# Altium schematic sheet Potencia.SchDoc
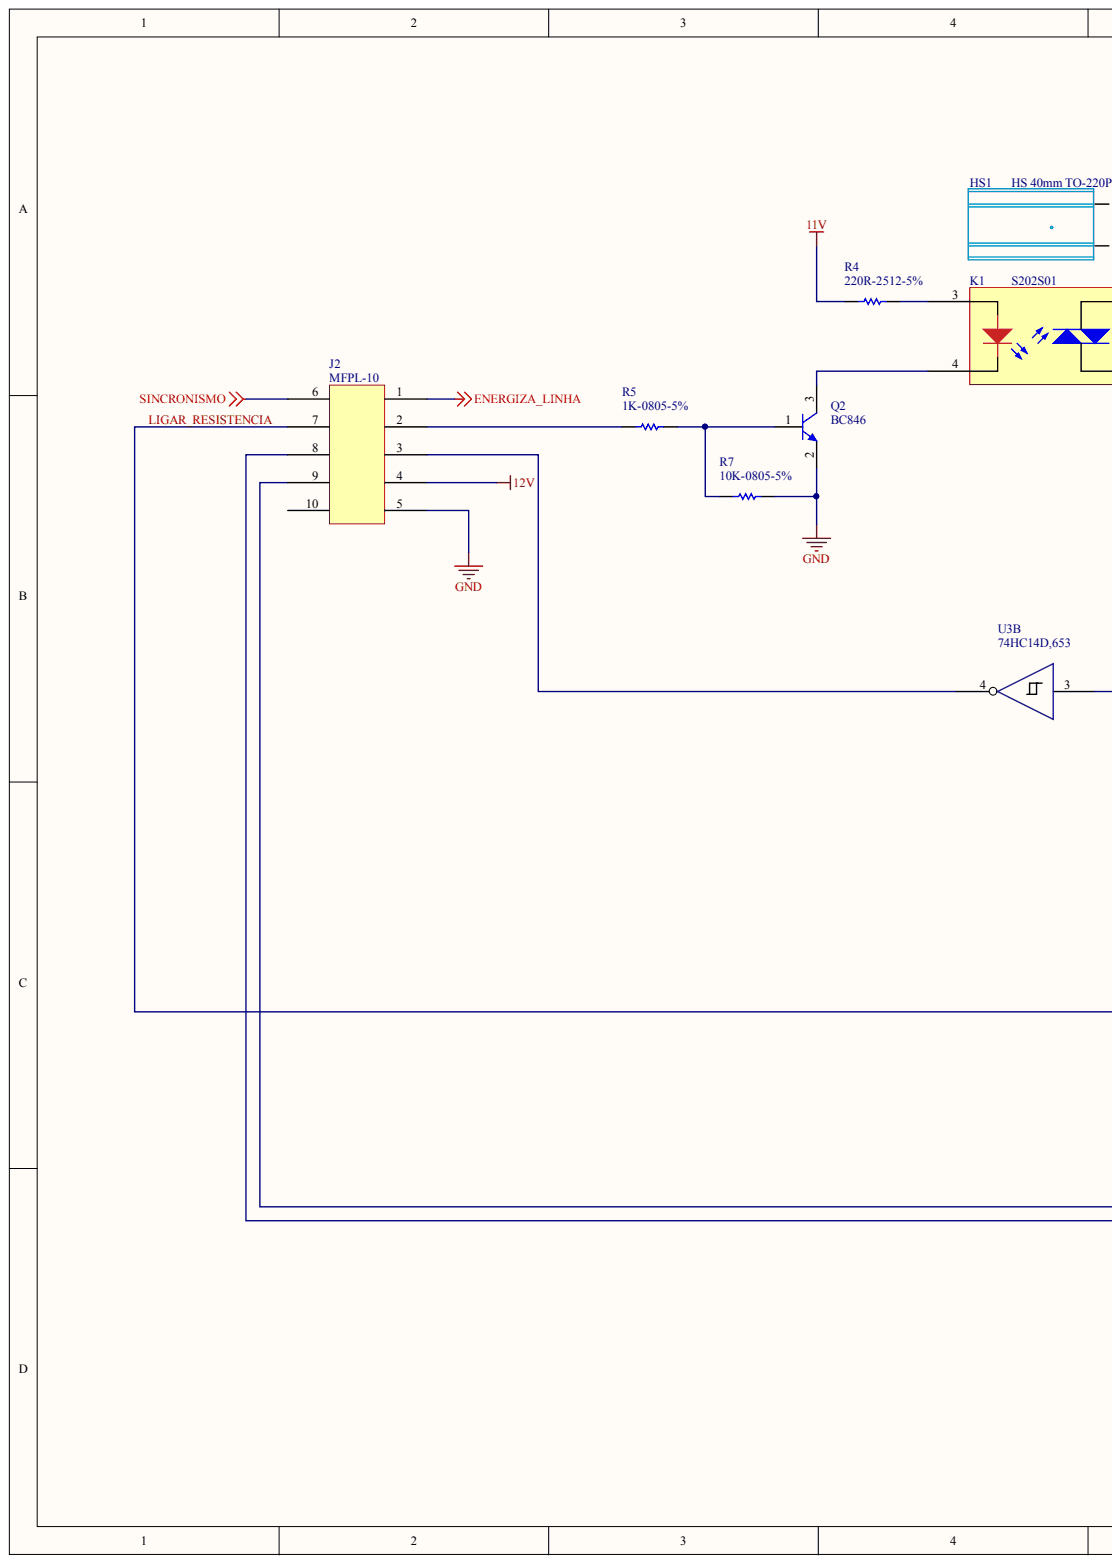
[diagram p0: Potencia.SchDoc, left]
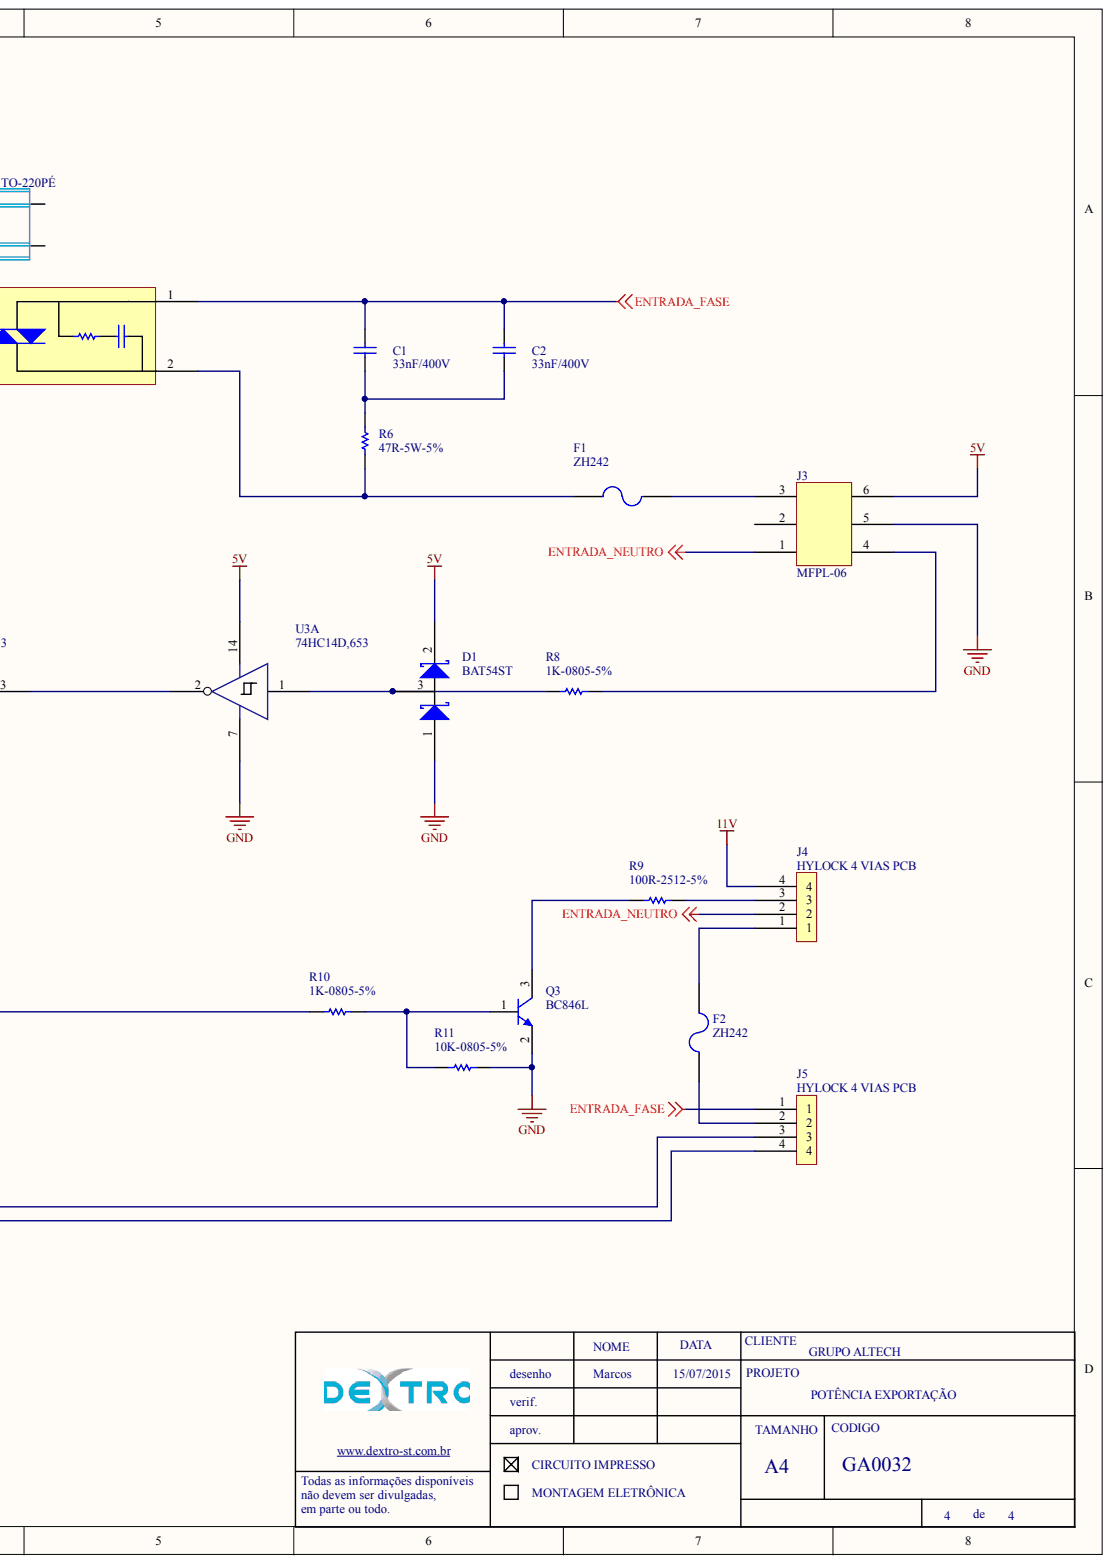
[diagram p1: Potencia.SchDoc, right]

## Parts
C1  33nF/400V  [cap 330nF/250V]
C2  33nF/400V  [cap 330nF/250V]
D1  BAT54ST  [SOT23 - REVISADO ONDA]
F1  ZH242  [ZH242_REVISADO]
F2  ZH242  [ZH242_REVISADO]
HS1  HS 40mm TO-220PÉ  [dissipador grande]
J2  MFPL-10  [MFPC-10]
J3  MFPL-06  [MFPC-06]
J4  HYLOCK 4 VIAS PCB  [CONECTOR HYLOK 4 VIAS]
J5  HYLOCK 4 VIAS PCB  [CONECTOR HYLOK 4 VIAS]
K1  S202S01  [S202S02]
Q2  BC846  [SOT23 - REVISADO ONDA]
Q3  BC846L
R4  220R-2512-5%  [2512 - REVISADO ONDA]
R5  1K-0805-5%  [0805-REVISADO ONDA]
R6  47R-5W-5%  [RESISTOR 5W FIO]
R7  10K-0805-5%  [0805-REVISADO ONDA]
R8  1K-0805-5%  [0805-REVISADO ONDA]
R9  100R-2512-5%  [2512 - REVISADO ONDA]
R10  1K-0805-5%  [0805-REVISADO ONDA]
R11  10K-0805-5%  [0805-REVISADO ONDA]
U3  74HC14D,653  [SOIC 14 PINOS]  (parts A,B)

## Nets
5V: D1.2 J3.6 U3.14
11V: J4.4 R4.2
12V: J2.4
ENERGIZA_LINHA: J2.1
ENTRADA_FASE: C1.2 C2.2 J5.1 K1.1
ENTRADA_NEUTRO: J3.1 J4.2
GND: D1.1 J2.5 J3.5 Q2.2 Q3.2 R7.2 R11.2 U3.7
SINCRONISMO: J2.6
LIGAR_RESISTENCIA: J2.7 R10.1
NetC1_1: C1.1 C2.1 R6.1
NetD1_3: D1.3 R8.1 U3.1
NetF1_1: F1.1 J3.3
NetF1_2: F1.2 K1.2 R6.2
NetF2_1: F2.1 J4.1
NetF2_2: F2.2 J5.2
NetJ2_2: J2.2 R5.1
NetJ2_3: J2.3 U3.4
NetJ2_8: J2.8 J5.4
NetJ2_9: J2.9 J5.3
NetJ3_4: J3.4 R8.2
NetJ4_3: J4.3 R9.2
NetK1_3: K1.3 R4.1
NetK1_4: K1.4 Q2.3
NetQ2_1: Q2.1 R5.2 R7.1
NetQ3_1: Q3.1 R10.2 R11.1
NetQ3_3: Q3.3 R9.1
NetU3_2: U3.2 U3.3

Not connected: HS1 0 1; J2 10; J3 2

## Library data of the parts
C1 C2 = 100nF/50-0805-NPO: Component Kind: Standard; Description: 100nF/50-0805-NPO; ERP: 104.11.005; Library Name: AquinoLib.SchLib
F1 F2 = PORTA FUSÍVEL TIPO BERÇO: Component Kind: Standard; Description: PORTA FUSÍVEL TIPO BERÇO; ERP: 426.00.002; Library Name: ChaveDigital3.SchLib
HS1 = DISSIPADOR 40mm PÉ: Component Kind: Standard; Library Name: ChaveDigital3.SchLib
J4 J5 = PCMC2-4: Component Kind: Standard; Description: PCMC2-4; Library Name: AquinoLib.SchLib
K1 = S102S12: Component Kind: Standard; Description: S102S12; Library Name: AquinoLib.SchLib
Q2 = BC846: BC846L; Component Kind: Standard; ERP: 106.22.001; Library Name: AquinoLib.SchLib
Q3 = BC846: Component Kind: Standard; Description: BC846L; ERP: 106.22.001; Library Name: AquinoLib.SchLib
R5 = 10K-0805-5%: Component Kind: Standard; Description: 10K-0805-5%; ERP: 102.11.054; Library Name: AquinoLib.SchLib
R9 = 100R-0805-5%: Component Kind: Standard; Description: 100R-0805-5%; ERP: 102.11.031; Library Name: AquinoLib.SchLib
U3 = 74HC14D: Component Kind: Standard; Description: 74HC14D; Library Name: AquinoLib.SchLib
D1 = BAT54ST: Component Kind: Standard; Description: BAT54ST; Library Name: AquinoLib.SchLib
J2 = MFPL-10: Component Kind: Standard; Description: MFPL-10; Library Name: AquinoLib.SchLib
J3 = MFPL-06: Component Kind: Standard; Description: MFPL-06; Library Name: AquinoLib.SchLib
R4 = 220R-2512-5%: Component Kind: Standard; Description: 220R-2512-5%; ERP: 102.31.000; Library Name: AquinoLib.SchLib
R6 = 47R-5W-5%: Component Kind: Standard; Description: 47R-5W-5%; ERP: 103.16.005; Library Name: AquinoLib.SchLib
R7 R11 = 10K-0805-5%: Component Kind: Standard; Description: 10K-0805-5%; ERP: 102.11.054; Library Name: AquinoLib.SchLib
R8 R10 = 1K-0805-5%: Component Kind: Standard; Description: 1K-0805-5%; ERP: 102.11.042; Library Name: AquinoLib.SchLib
